# Altium schematic sheet 01rng.SchDoc(01rng)
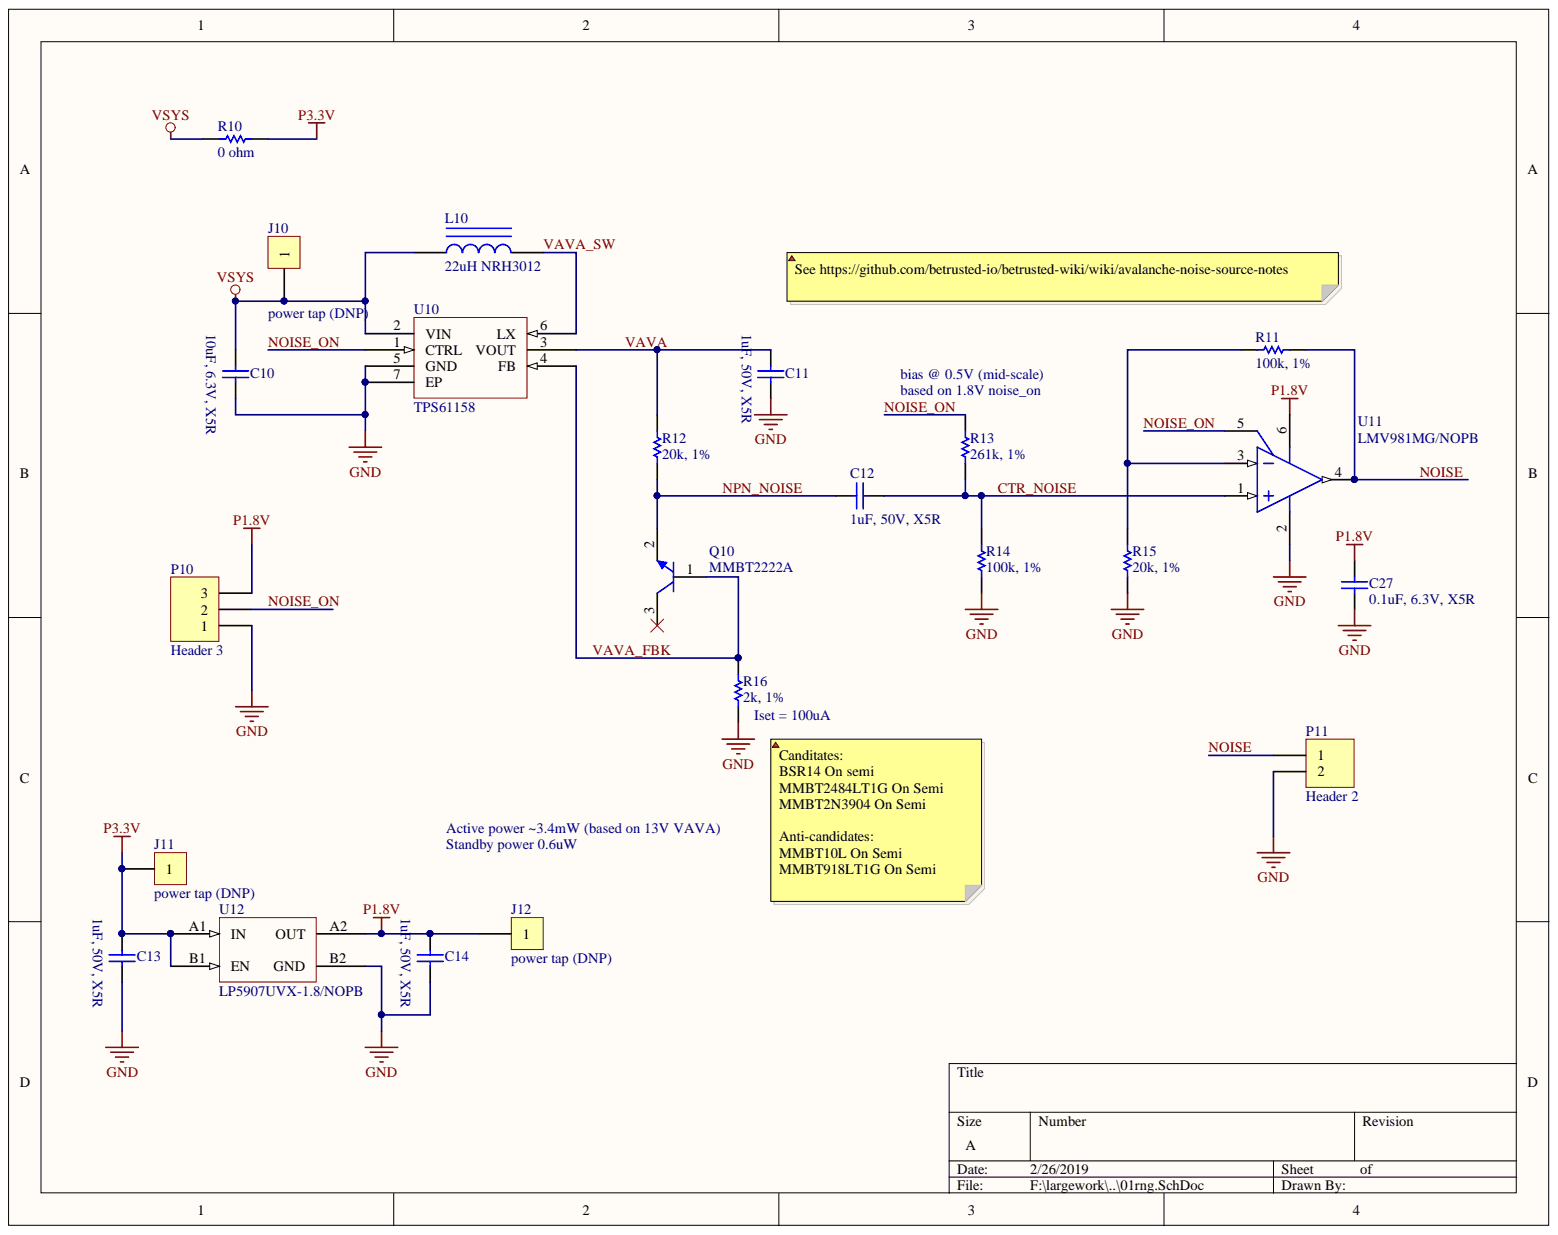
[diagram p0: 01rng.SchDoc]

## Parts
C10  10uF, 6.3V, X5R  [B_CAP0603]
C11  1uF, 50V, X5R  [B_CAP0603]
C12  1uF, 50V, X5R  [B_CAP0603]
C13  1uF, 50V, X5R  [B_CAP0603]
C14  1uF, 50V, X5R  [B_CAP0603]
C27  0.1uF, 6.3V, X5R  [B_CAP0603]
J10  power tap (DNP)  [RESTAP-0603]
J11  power tap (DNP)  [RESTAP-0603]
J12  power tap (DNP)  [RESTAP-0603]
L10  22uH NRH3012  [TAIYO_NRH3012]
P10  Header 3  [HDR1X3]
P11  Header 2  [HDR1X2]
Q10  MMBT2222A  [ONSC-SOT-23-3-318-08_V]
R10  0 ohm  [B_RES0603]
R11  100k, 1%  [B_RES0402]
R12  20k, 1%  [B_RES0402]
R13  261k, 1%  [B_RES0402]
R14  100k, 1%  [B_RES0402]
R15  20k, 1%  [B_RES0402]
R16  2k, 1%  [B_RES0402]
U10  TPS61158  [SON65P200X80_HS-7N]
U11  LMV981MG/NOPB  [DCK0006A_N]
U12  LP5907UVX-1.8/NOPB  [TI_YKE004]

## Nets
GND: C10.2 C11.2 C13.2 C14.2 C27.1 P10.1 P11.2 R14.1 R15.1 R16.1 U10.5(GND) U10.7(EP) U11.2 U12.B2(GND)
P1.8V: C14.1 C27.2 J12.1 P10.3 U11.6 U12.A2(OUT)
P3.3V: C13.1 J11.1 R10.2 U12.A1(IN) U12.B1(EN)
VSYS: C10.1 J10.1 L10.1 R10.1 U10.2(VIN)
CTR_NOISE: C12.2 R13.1 R14.2 U11.1
NOISE: P11.1 R11.1 U11.4
NOISE_ON: P10.2 R13.2 U10.1(CTRL) U11.5
NPN_NOISE: C12.1 Q10.2 R12.1
VAVA: C11.1 R12.2 U10.3(VOUT)
VAVA_FBK: Q10.1 R16.2 U10.4(FB)
VAVA_SW: L10.2 U10.6(LX)
NetR11_2: R11.2 R15.2 U11.3

Not connected: Q10 3

## Library data of the parts
C10 = Cap Semi: Capacitor (Semiconductor SIM Model); MPN: CL10A106MQ8NNNC; VENDOR: Samsung; MYPN: C-106-6.3-X5R-0603; Simulation: CAP; Signal Integrity: Cap Semi; Library Name: Miscellaneous Devices.IntLib; Component Kind: Standard
C11-C14 = Cap Semi: Capacitor (Semiconductor SIM Model); MPN: CL10A105KB8NNNC; VENDOR: Samsung; MYPN: C-105-50-X5R-0603; Simulation: CAP; Signal Integrity: Cap Semi; Library Name: Miscellaneous Devices.IntLib; Component Kind: Standard
C27 = Cap Semi: Capacitor (Semiconductor SIM Model); VENDOR: ANY; MYPN: C-104-6.3-X5R-0603; Simulation: CAP; Signal Integrity: Cap Semi; MPN: ANY; Library Name: Miscellaneous Devices.IntLib; Component Kind: Standard
J10-J12 = CON1: Connector; MYPN: J-TP-PWRTAP-0603; VENDOR: DNP; MPN: DNP; Component Kind: Standard
L10 = Inductor Iron: Magnetic-Core Inductor; MPN: NRH3012T220MN; VENDOR: Taiyo Yuden; MYPN: L-NRH3012T220MN; Simulation: INDUCTOR; Signal Integrity: Inductor Iron; Library Name: Miscellaneous Devices.IntLib; Component Kind: Standard
P10 = Header 3: Header, 3-Pin; Signal Integrity: Connector; Library Name: Miscellaneous Connectors.IntLib; LatestRevisionNote: Re-released for DXP Platform.; LatestRevisionDate: 17-Jul-2002; Component Kind: Standard; Publisher: Altium Limited
P11 = Header 2: Header, 2-Pin; Signal Integrity: Connector; Library Name: Miscellaneous Connectors.IntLib; LatestRevisionNote: Re-released for DXP Platform.; LatestRevisionDate: 17-Jul-2002; Component Kind: Standard; Publisher: Altium Limited
Q10 = ONSC-NPN-B1E2C3-3: VENDOR: Diodes Inc; MYPN: Q-NPN-BCE-SOT23; MPN: MMBT2222A; Library Name: ONSC-NPN-B1E2C3-3.SchLib; Component Kind: Standard
R10 = Res1: Resistor; VENDOR: ANY; MYPN: R-0R0-1P-1_16W-0603; Simulation: RESISTOR; Signal Integrity: Res; MPN: ANY; Library Name: Miscellaneous Devices.IntLib; Component Kind: Standard
R11 R14 = Res1: Resistor; VENDOR: ANY; MYPN: R-104-1P-1_16W-0402; Simulation: RESISTOR; Signal Integrity: Res; MPN: ANY; Library Name: Miscellaneous Devices.IntLib; Component Kind: Standard
R12 R15 = Res1: Resistor; VENDOR: ANY; MYPN: R-203-1P-1_16W-0402; Simulation: RESISTOR; Signal Integrity: Res; MPN: ANY; Library Name: Miscellaneous Devices.IntLib; Component Kind: Standard
R13 = Res1: Resistor; VENDOR: ANY; MYPN: R-264-1P-1_16W-0402; Simulation: RESISTOR; Signal Integrity: Res; MPN: ANY; Library Name: Miscellaneous Devices.IntLib; Component Kind: Standard
R16 = Res1: Resistor; VENDOR: ANY; MYPN: R-202-1P-1_16W-0402; Simulation: RESISTOR; Signal Integrity: Res; MPN: ANY; Library Name: Miscellaneous Devices.IntLib; Component Kind: Standard
U10 = TPS61158: 30V WLED driver with integrated power diode; MPN: TPS61158DRVR; VENDOR: TI; MYPN: U-TPS61158DRVR; Library Name: commonsch-new.SchLib; Component Kind: Standard
U11 = CMP-0055-01099-3: Single 1.8V, RRIO Operational Amplifiers with Shutdown, 6-pin SC-70, Pb-Free; VENDOR: Texas Instruments; PowerWise: N; PackageTopMarking: A77*XTT; RoHS: Y; PinCount: 6; Package Reference: DCK0006A; PackageDescription: Molded SC-70, 2 x 1.25 x .9mm, 6 Lead, 0.65mm Pitch; MPN: LMV981MG/NOPB; Library Name: Altium Content Vault; CompType: Amplifiers; ComponentLink1URL: http://www.ti.com/general/docs/lit/getliterature.tsp?genericPartNumber=LMV981-N&fileType=pdf; ComponentLink1Description: Datasheet URL; Component Kind: Standard
U12 = LP5907UVX: Ultra low-noise 250mA LDO for RF and analog in DSBGA; VENDOR: TI; MYPN: ULP5907UVX-1.8; MPN: LP5907UVX-1.8/NOPB; Library Name: commonsch-new.SchLib; Component Kind: Standard
